# Altium schematic sheet cpuadcinput.SchDoc(cpuadcinput)
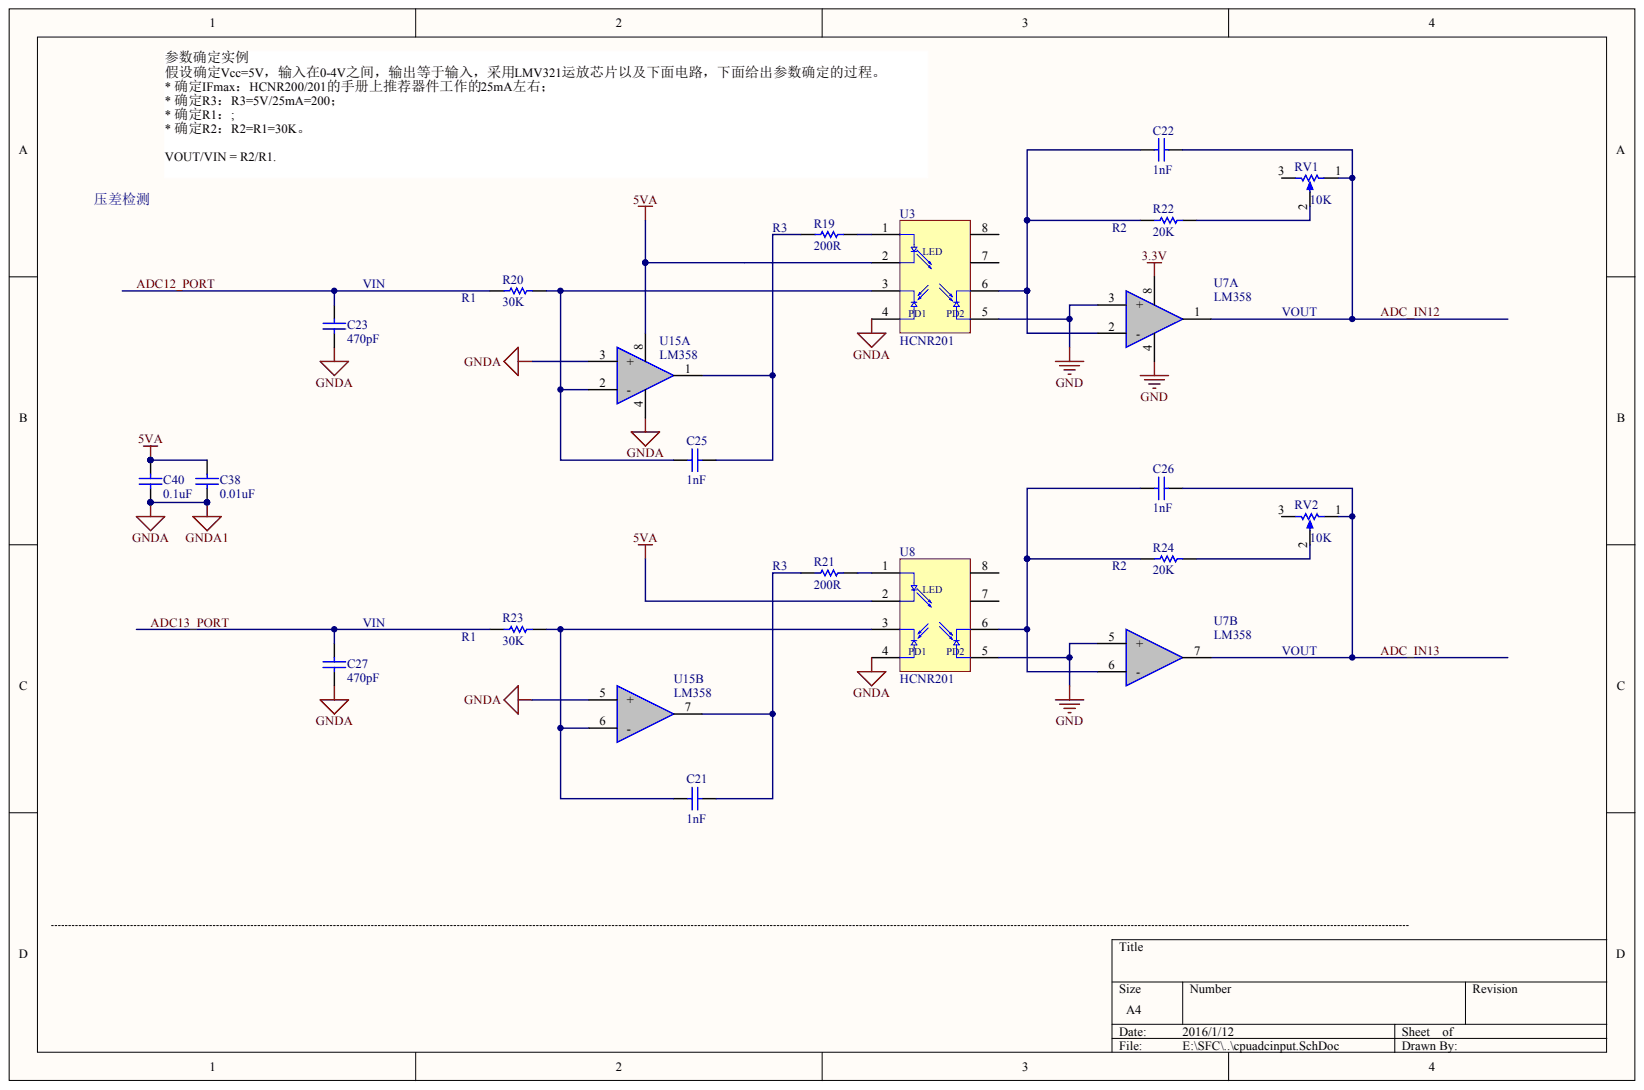
[diagram p0: cpuadcinput.SchDoc]

## Parts
C21  1nF
C22  1nF
C23  470pF
C25  1nF
C26  1nF
C27  470pF
C38  0.01uF
C40  0.1uF
R19  200R
R20  30K
R21  200R
R22  20K
R23  30K
R24  20K
RV1  10K
RV2  10K
U3  HCNR201
U7  LM358  (parts A,B)
U8  HCNR201
U15  LM358  (parts A,B)

## Nets
3.3V: U7.8
5VA: C38.2 C40.2 U3.2 U8.2 U15.8
GND: U3.5 U7.3(+) U7.4 U7.5(+) U8.5
GNDA: C23.1 C27.1 C38.1 C40.1 U3.4 U8.4 U15.3(+) U15.4 U15.5(+)
ADC12_PORT: C23.2 R20.2
ADC13_PORT: C27.2 R23.2
ADC_IN12: C22.2 RV1.1 U7.1
ADC_IN13: C26.2 RV2.1 U7.7
NetC21_1: C21.1 R23.1 U8.3 U15.6(-)
NetC21_2: C21.2 R21.1 U15.7
NetC22_1: C22.1 R22.2 U3.6 U7.2(-)
NetC25_1: C25.1 R20.1 U3.3 U15.2(-)
NetC25_2: C25.2 R19.1 U15.1
NetC26_1: C26.1 R24.2 U7.6(-) U8.6
NetR19_2: R19.2 U3.1
NetR21_2: R21.2 U8.1
NetR22_1: R22.1 RV1.2
NetR24_1: R24.1 RV2.2

Not connected: RV1 3; RV2 3; U3 7 8; U8 7 8

## Library data of the parts
C23 C27 C38 C40 = (no symbol name): Value: 1nF; Published: 8-Jun-2000; LatestRevisionDate: 17-Jul-2002; LatestRevisionNote: Re-released for DXP Platform.; PackageReference: RAD-0.3; Publisher: Altium Limited
R19-R24 = (no symbol name): Value: 10K; Published: 8-Jun-2000; LatestRevisionDate: 17-Jul-2002; LatestRevisionNote: Re-released for DXP Platform.; PackageReference: AXIAL-0.3; Publisher: Altium Limited
RV1 RV2 = (no symbol name): Sim Note: Enter the Resistance value and the set point into parameter fields.; Set Position: 0.5; Value: 1K; Published: 8-Jun-2000; LatestRevisionDate: 17-Jul-2002; LatestRevisionNote: Re-released for DXP Platform.; PackageReference: VR5; Publisher: Altium Limited
C21 C22 C25 C26 U3 U7 U8 U15 = (no symbol name)
  C21 C22 C25 C26: Published: 8-Jun-2000; LatestRevisionDate: 17-Jul-2002; LatestRevisionNote: Re-released for DXP Platform.; PackageReference: RAD-0.3; Value: 1nF; Publisher: Altium Limited
